# Altium schematic sheet Schematic.SchDoc(Schematic)
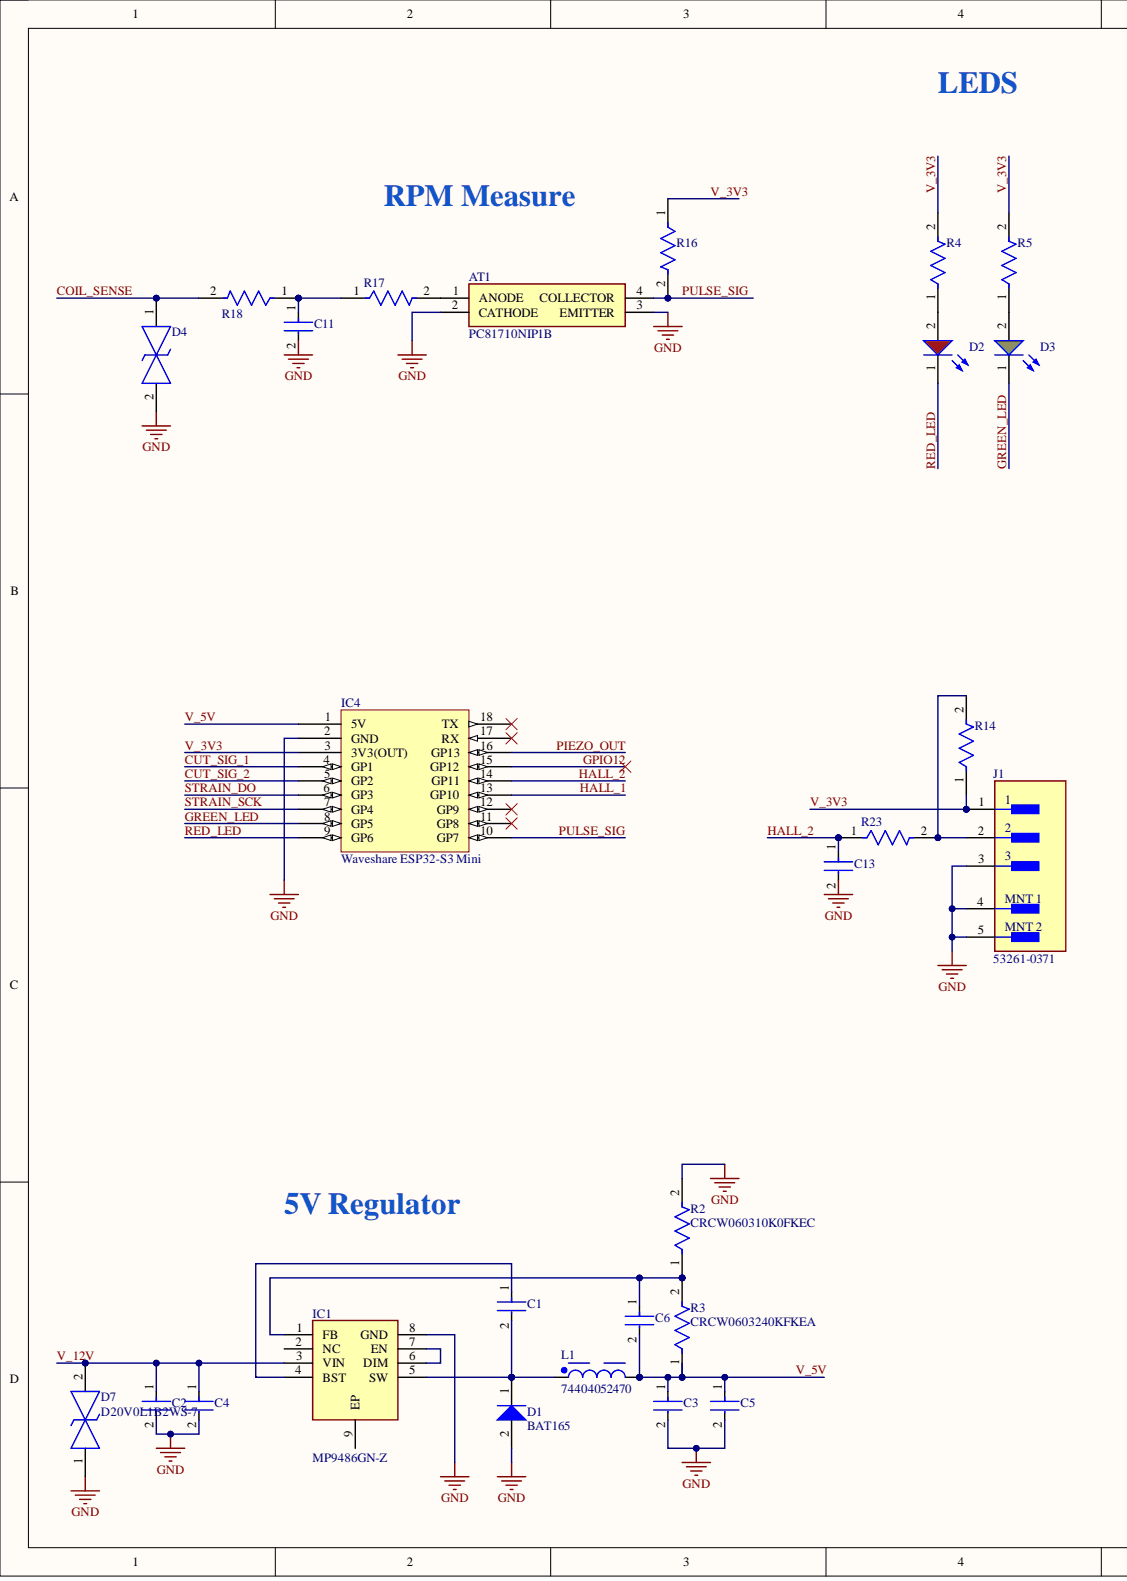
[diagram p0: Schematic.SchDoc, left]
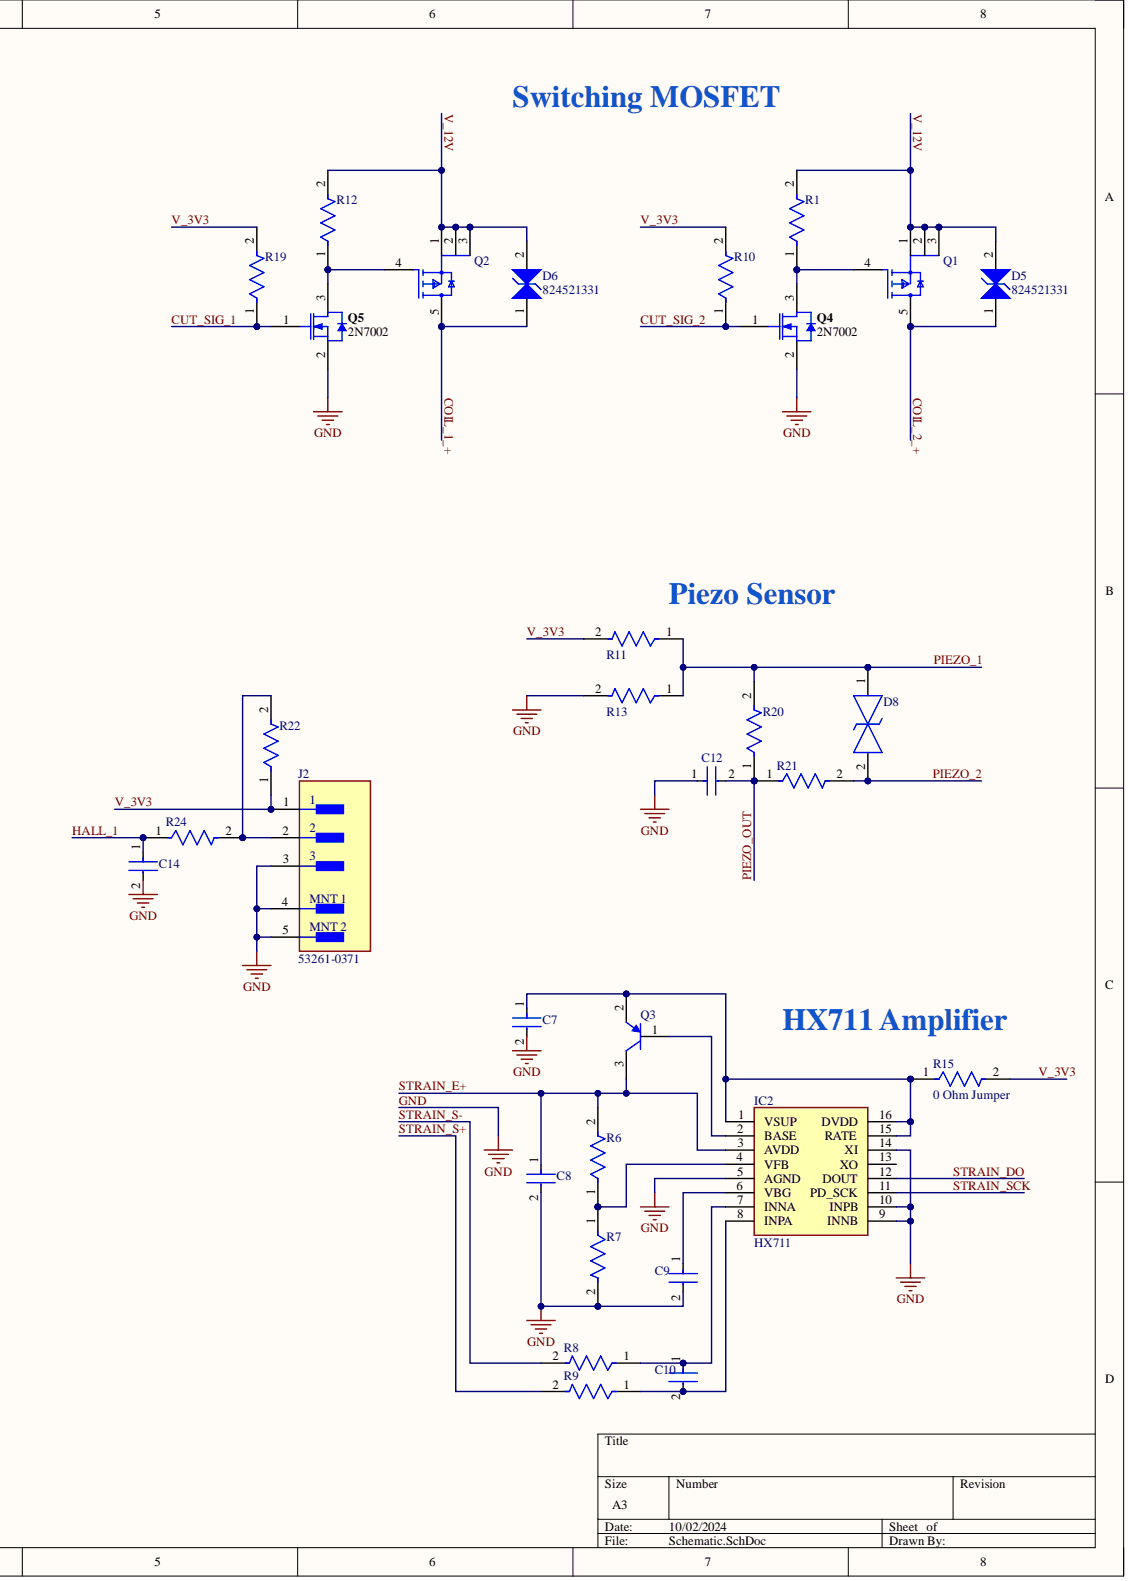
[diagram p1: Schematic.SchDoc, right]

## Parts
AT1  PC81710NIP1B
C1  C0603C104K3PACTU  (value not drawn)
C2  C3216X5R1E476M160AC  (value not drawn)
C3  C3216X5R1E476M160AC  (value not drawn)
C4  C0603C104K3PACTU  (value not drawn)
C5  C0603C225K4PACTU  (value not drawn)
C6  C0603C104K3PACTU  (value not drawn)
C7  C0603C106M8PACTU  (value not drawn)
C8  C0603C106M8PACTU  (value not drawn)
C9  C0603C104K3PACTU  (value not drawn)
C10  C0603C104K3PACTU  (value not drawn)
C11  C0603C333K1RECAUTO  (value not drawn)
C12  C0603C473K3RACTU  (value not drawn)
C13  CL10A105KA8NNNC  (value not drawn)
C14  CL10A105KA8NNNC  (value not drawn)
D1  BAT165
D2  150080RS75000  (value not drawn)
D3  150080GS75000  (value not drawn)
D4  D20V0L1B2WS-7
D5  824521331
D6  824521331
D7  D20V0L1B2WS-7
D8  D20V0L1B2WS-7
IC1  MP9486GN-Z
IC2  HX711
IC4  Waveshare ESP32-S3 Mini
J1  53261-0371
J2  53261-0371
L1  74404052470
Q1  SQJ459EP-T1_GE3  (value not drawn)
Q2  SQJ459EP-T1_GE3  (value not drawn)
Q3  MMBT4403LT1G  (value not drawn)
Q4  2N7002
Q5  2N7002
R1  CRCW060310K0FKEC
R2  CRCW060310K0FKEC
R3  CRCW0603240KFKEA
R4  CRCW0603100RFKEB  (value not drawn)
R5  CRCW0603100RFKEB  (value not drawn)
R6  CRCW060310K0FKEC
R7  CRCW06038K20FKEC  (value not drawn)
R8  CRCW0603100RFKEB  (value not drawn)
R9  CRCW0603100RFKEB  (value not drawn)
R10  CRCW060310K0FKEC
R11  CRCW060310K0FKEC
R12  CRCW060310K0FKEC
R13  CRCW060310K0FKEC
R14  CRCW06033K30FKEA  (value not drawn)
R15  0 Ohm Jumper
R16  CRCW060310K0FKEC
R17  RMCF0603FT2K20  (value not drawn)
R18  CRCW060310K0FKEC
R19  CRCW060310K0FKEC
R20  CRCW06032M00FKEA  (value not drawn)
R21  CRCW060310K0FKEC
R22  CRCW06033K30FKEA  (value not drawn)
R23  CRCW060320K0FKEA  (value not drawn)
R24  CRCW060320K0FKEA  (value not drawn)

## Nets
COIL_1_+: D6.1 Q2.5
COIL_2_+: D5.1 Q1.5
COIL_SENSE: D4.1 R18.2
CUT_SIG_1: IC4.4(GP1) Q5.1 R19.1
CUT_SIG_2: IC4.5(GP2) Q4.1 R10.1
GND: AT1.2(CATHODE) AT1.3(EMITTER) C2.2 C3.2 C4.2 C5.2 C7.2 C8.2 C9.2 C11.2 C12.1 C13.2 C14.2 D1.2 D4.2 D7.1 IC1.8(GND) IC2.5(AGND) IC2.9(INNB) IC2.10(INPB) IC2.14(XI) IC4.2(GND) J1.3 J1.4 J1.5 J2.3 J2.4 J2.5 Q4.2 Q5.2 R2.2 R7.2 R13.2
GPIO12: IC4.15(GP12)
GREEN_LED: D3.1 IC4.8(GP5)
HALL_1: C14.1 IC4.13(GP10) R24.1
HALL_2: C13.1 IC4.14(GP11) R23.1
PIEZO_1: D8.1 R11.1 R13.1 R20.2
PIEZO_2: D8.2 R21.2
PIEZO_OUT: C12.2 IC4.16(GP13) R20.1 R21.1
PULSE_SIG: AT1.4(COLLECTOR) IC4.10(GP7) R16.2
RED_LED: D2.1 IC4.9(GP6)
STRAIN_DO: IC2.12(DOUT) IC4.6(GP3)
STRAIN_E+: C8.1 IC2.3(AVDD) Q3.3 R6.2
STRAIN_S+: R9.2
STRAIN_S-: R8.2
STRAIN_SCK: IC2.11(PD_SCK) IC4.7(GP4)
V_3V3: IC4.3(3V3(OUT)) J1.1 J2.1 R4.2 R5.2 R10.2 R11.2 R14.1 R15.2 R16.1 R19.2 R22.1
V_5V: C3.1 C5.1 C6.2 IC4.1(5V) L1.2 R3.1
V_12V: C2.1 C4.1 D5.2 D6.2 D7.2 IC1.3(VIN) Q1.1 Q1.2 Q1.3 Q2.1 Q2.2 Q2.3 R1.2 R12.2
NetAT1_1: AT1.1(ANODE) R17.2
NetC1_1: C1.1 IC1.4(BST)
NetC1_2: C1.2 D1.1 IC1.5(SW) L1.1
NetC6_1: C6.1 IC1.1(FB) R2.1 R3.2
NetC7_1: C7.1 IC2.1(VSUP) IC2.15(RATE) IC2.16(DVDD) Q3.2 R15.1
NetC9_1: C9.1 IC2.6(VBG)
NetC10_1: C10.1 IC2.7(INNA) R8.1
NetC10_2: C10.2 IC2.8(INPA) R9.1
NetC11_1: C11.1 R17.1 R18.1
NetD2_2: D2.2 R4.1
NetD3_2: D3.2 R5.1
NetIC1_6: IC1.6(DIM) IC1.7(EN)
NetIC2_2: IC2.2(BASE) Q3.1
NetIC2_4: IC2.4(VFB) R6.1 R7.1
NetJ1_2: J1.2 R14.2 R23.2
NetJ2_2: J2.2 R22.2 R24.2
NetQ1_4: Q1.4 Q4.3 R1.1
NetQ2_4: Q2.4 Q5.3 R12.1

Not connected: IC1 2(NC) 9(EP); IC2 13(XO); IC4 11(GP8) 12(GP9) 17(RX) 18(TX)

## Library data of the parts
AT1 = PC81710NIP1B: Attenuator or Isolator; Manufacturer_Name: Sharp Microelectronics; Component Kind: Standard; Datasheet Link: https://global.sharp/products/device/lineup/data/pdf/datasheet/PC8171xNSZ1B_e.pdf; Height: 4.2mm; Manufacturer_Part_Number: PC81710NIP1B; Mouser Part Number: 852-PC81710NIP1B; Mouser Price/Stock: https://www.mouser.co.uk/ProductDetail/Sharp-Microelectronics/PC81710NIP1B?qs=MLItCLRbWswN8lAuXmPENg%3D%3D
C1 C4 C6 C9 C10 = CMP-03020-000352-2: C0603 0.10 μF X5R 30ppm/°C 10.00% 25 V; Manufacturer: KEMET; Automotive Grade: Grade 1; Capacitance: 0.10 μF; Material: Ceramic; Tolerance: 0.1; Value: 0.10 μF; Voltage Rating: 25 V; Automotive: Yes; Category: Cap; Component Kind: Standard; ComponentLink2Description: Datasheet; ComponentLink2URL: https://api.kemet.com/component-edge/download/datasheet/C0603C100J5GACAUTO.pdf; Device Class L1: Passive Components; Device Class L2: Capacitors; Device Class L3: Ceramic Capacitors; Height: 0.87mm; Lead Free: Yes; Library Name: Altium Content Vault; Manufacturer Part Number: C0603C104K3PACTU; Mouser Description: Multilayer Ceramic Capacitors MLCC - SMD/SMT 25volts 0.1uF 10% X5R; Octopart: https://octopart.com/c0603c104k3pactu-kemet-19022554; Package: C0603C-CF; RoHS: TRUE; Temperature: -55°C to +125°C; Temperature Characteristic: X5R; Temperature Coefficient: 30ppm/°C; Temperature Range High: +125°C; Temperature Range Low: -55°C
C2 C3 = CMP-2000-05397-3: Multilayer Ceramic Capacitors 47uF ±20% 25V X5R SMD 1206; Capacitance: 47uF; Case Code (Imperial): 1206; Case Code (Metric): 3216; Case/Package: 1206; Component Kind: Standard; Depth: 1.6mm; Dielectric: X5R; Dielectric Material: Ceramic; Height: 1.6mm; Lead Free: Lead Free; Length: 0.126inch; Library Name: Altium Content Vault; Max Operating Temperature: 85°C; Min Operating Temperature: -55°C; Mount: Surface Mount; Package Quantity: 1; Packaging: Tape and Reel; REACH SVHC: No SVHC; Resistor Type: Ceramic; RoHS Compliant: Yes; Series: C; Termination: SMD/SMT; Thickness: 0.071inch; Tolerance: 20%; Voltage: 25V; Voltage Rating: 25V; Voltage Rating (DC): 25V; Weight: 0.000571oz; Width: 0.063inch
C5 = CMP-2006-02259-2: CAP CER 2.2UF 16V X5R 0603; Dielectric Material: Ceramic; Dissipation Factor: 5%; Tolerance: 10%; Capacitance: 2.2uF; Case Code (Imperial): 0603; Case Code (Metric): 1608; Case/Package: 0603; Component Kind: Standard; Construction: Flat; Contact Plating: Tin; Depth: 0.8mm; Dielectric: X5R; Height: 0.8mm; Lead Free: Lead Free; Length: 1.6mm; Library Name: Altium Content Vault; Max Operating Temperature: 85°C; Min Operating Temperature: -55°C; Mount: Surface Mount; Number of Pins: 2; Package Quantity: 1; Packaging: Tape and Reel; Radiation Hardening: No; REACH SVHC: No SVHC; RoHS Compliant: Yes; Series: C; Termination: SMD/SMT; Thickness: 0.034inch; Voltage: 16V; Voltage Rating: 16V; Voltage Rating (DC): 16V; Width: 0.8mm
C7 C8 = CMP-03020-034654-1: CAP CER 10UF 10V X5R 0603; Component Kind: Standard; Library Name: Altium Content Vault; RoHS Compliant: Yes
C11 = CMP-00212-78735637-1: C0603C333K1RECAUTO 0603 (1608METRIC) Ceramic Capacitor Esd SMD Auto X7R 100V 0.033MUF ±10% X7R; Capacitance: 33nF; Component Kind: Standard; Dielectric: X7R; Library Name: Altium Content Vault; NexarPartId: 78735637; Packaging: Cut Tape; RoHS: Non-Compliant; Voltage Rating (DC): 100V
C12 = CMP-2006-03882-2: CAP CER 0.047UF 25V 10% X7R 0603; Manufacturer: KEMET; Automotive Grade: Grade 1; Capacitance: 47,000 pF; Material: Ceramic; Temperature Coefficient: 30ppm/°C; Tolerance: 0.1; Value: 47,000 pF; Automotive: Yes; Category: Cap; Component Kind: Standard; ComponentLink2Description: Datasheet; ComponentLink2URL: https://api.kemet.com/component-edge/download/datasheet/C0603C100J5GACAUTO.pdf; Device Class L1: Passive Components; Device Class L2: Capacitors; Device Class L3: Ceramic Capacitors; Digikey Description: CAP CER 0.047UF 25V 10% X7R 0603; Height: 0.87mm; Lead Free: Yes; Library Name: Altium Content Vault; Manufacturer Part Number: C0603C473K3RACTU; Mouser Description: Multilayer Ceramic Capacitor, C Series, 0.047 - F, - 10%, X7R, 25 V, 0603 [1608 Metric] Rohs Compliant: Yes; Octopart: https://octopart.com/c0603c473k3ractu-kemet-3834514; Package: C0603C-CF; RoHS: TRUE; Temperature: -55°C to +125°C; Temperature Characteristic: X7R; Temperature Range High: +125°C; Temperature Range Low: -55°C; Voltage Rating: 25 V
C13 C14 = CMP-13271-004127-1: Cap Ceramic 1uF 25V X5R ±10% Pad SMD 0603 +85°C T/R; Capacitance: 1uF; Case Code (Imperial): 0603; Case Code (Metric): 1608; Case/Package: 0603; Component Kind: Standard; Contact Plating: Tin; Depth: 0.8mm; Dielectric: X5R; Height: 0.8mm; Lead Free: Lead Free; Length: 1.6mm; Library Name: Altium Content Vault; Material: Ceramic; Max Operating Temperature: 85°C; Min Operating Temperature: -55°C; Mount: Surface Mount; Package Quantity: 1; Packaging: Tape and Reel; Radiation Hardening: No; Thickness: 0.035inch; Tolerance: 10%; Voltage: 25V; Voltage Rating: 25V; Voltage Rating (DC): 25V; Width: 0.031inch
D1 = CMP-1183-00004-1: Medium Power AF Schottky Diode, SOD323, Reel, Green; Manufacturer: Infineon; Configuration: Single; CT (max): 12Â pF; Green: TRUE; IF (max): 750Â mA; VF (max): 700Â mV; VR (max): 40Â V; Component Kind: Standard; ComponentLink1Description: Manufacturer URL; ComponentLink1URL: http://www.infineon.com/; ComponentLink2Description: Datasheet; ComponentLink2URL: http://www.infineon.com/dgdl/bat165series.pdf?folderId=db3a304313d846880113def5812204a1&fileId=db3a304313d846880113df01f69804d6; ComponentLink3Description: Package Specification; ComponentLink3URL: http://www.infineon.com/dgdl/SOD323-Package_Overview.pdf?folderId=db3a30431936bc4b011952aab84f3bbe&fileId=db3a30431936bc4b011952beed653be3; Library Name: Altium Content Vault; Mounting Technology: Surface Mount; PackageDescription: 2-Pin Small Outline Diode Package, 1.25 x 1.7 mm Body; PackageReference: SOD323; Packing: Reel; PartNumber: BAT165
D2 = CMP-1426-00011-2: NexarPartId: 29717781; Color: Red; Dominant Wavelength: 625nm; Forward Current: 100mA; Forward Voltage: 2V; Lens Style: Clear, Rectangular; Luminous Intensity: 150mcd; Max Reverse Voltage (DC): 5V; Peak Wavelength: 630nm; Test Current: 20mA; Viewing Angle: 140°; Case Code (Imperial): 0805; Case Code (Metric): 2012; Case/Package: 0805; Component Kind: Standard; Height: 700µm; Length: 2mm; Library Name: Altium Content Vault; Max Operating Temperature: 85°C; Min Operating Temperature: -40°C; Mount: Surface Mount; Packaging: Tape and Reel; REACH SVHC: Unknown; RoHS: Compliant; Wavelength: 625nm; Width: 1.25mm
D3 = CMP-1426-00007-2: NexarPartId: 29717780; Color: Green; Dominant Wavelength: 525nm; Forward Current: 100mA; Forward Voltage: 3.2V; Lens Style: Clear, Rectangular; Luminous Intensity: 450mcd; Max Junction Temperature (Tj): 95°C; Max Reverse Voltage (DC): 5V; Peak Wavelength: 515nm; Power Dissipation: 105mW; Test Current: 20mA; Viewing Angle: 140°; Wavelength: 520nm; Case Code (Imperial): 0805; Case Code (Metric): 2012; Case/Package: 0805; Component Kind: Standard; Height: 700µm; Length: 2mm; Library Name: Altium Content Vault; Max Operating Temperature: 85°C; Min Operating Temperature: -40°C; Mount: Surface Mount; Packaging: Tape and Reel; REACH SVHC: Unknown; Reverse Voltage: 5V; RoHS: Compliant; Width: 1.25mm
D4 D7 D8 = D20V0L1B2WS-7: TVS Diode (Bi-directional); Manufacturer_Name: Diodes Incorporated; Component Kind: Standard; Datasheet Link: https://www.diodes.com/assets/Datasheets/D20V0L1B2WSQ.pdf; Height: 1.2mm; Manufacturer_Part_Number: D20V0L1B2WS-7
D5 D6 = CMP-03913-71101627-1: 824521331 TVS diode [Würth Elektronik] 824521331 TVS diode; Breakdown Voltage: 38.65V; Clamping Voltage: 53.3V; Leakage Current: 1µA; Number of Bidirectional Channels: 1; Peak Pulse Current: 11.3A; Peak Pulse Power: 600W; Polarity: Bidirectional; Power Line Protection: No; Reverse Standoff Voltage: 33V; Component Kind: Standard; Composition: Zener; Height: 2.4mm; Length: 4.32mm; Library Name: Altium Content Vault; Max Breakdown Voltage: 38.65V; Max Operating Temperature: 150°C; Min Breakdown Voltage: 38.65V; Min Operating Temperature: -65°C; Mount: Surface Mount; NexarPartId: 71101627; Number of Pins: 2; Packaging: Cut Tape; REACH SVHC: Unknown; RoHS: Compliant; Width: 3.62mm
IC1 = MP9486GN-Z: Integrated Circuit; Manufacturer_Name: Monolithic Power Systems (MPS); Component Kind: Standard; Datasheet Link: https://www.monolithicpower.com/en/documentview/productdocument/index/version/2/document_type/Datasheet/lang/en/sku/MP9486/; Height: 1.7mm; Manufacturer_Part_Number: MP9486GN-Z; Mouser Part Number: 946-MP9486GN-Z; Mouser Price/Stock: https://www.mouser.co.uk/ProductDetail/Monolithic-Power-Systems-MPS/MP9486GN-Z?qs=YCa%2FAAYMW039h5ckyQnXtA%3D%3D
IC2 = HX711: Integrated Circuit; Manufacturer_Name: AVIA; Component Kind: Standard; Datasheet Link: https://cdn.sparkfun.com/datasheets/Sensors/ForceFlex/hx711_english.pdf; Height: 1.6mm; Manufacturer_Part_Number: HX711
IC4 = CMP-00000-1: WaveShare ESP32-S3 Mini Development Board ESP32-S3FH4R2 240MHz 2.4GHz Wi-Fi Bluetooth 5; Component Kind: Standard; Datasheet: https://www.waveshare.com/wiki/ESP32-S3-Zero; Library Name: Sandros Projects
J1 J2 = CMP-04776-000071-1: CONN HEADER SMD R/A 3POS 1.25MM; Manufacturer: Molex; Conn Gender: Male; Conn Orientation: Right Angle; Current Rating: 1A; Number Of Contacts: 3; Voltage Rating: 125V; Ambient Temperature Range High: +105°C; Ambient Temperature Range Low: -40°C; Automotive: No; Category: Conn; Component Kind: Standard; ComponentLink2Description: Datasheet; ComponentLink2URL: https://www.molex.com/webdocs/datasheets/pdf/en-us/0532610371_PCB_HEADERS.pdf; Contact Material: Tin,Nickel; Device Class L1: Connectors; Device Class L2: Headers and Wire Housings; Device Class L3: unset; Digikey Description: CONN HEADER SMD R/A 3POS 1.25MM; Footprint Url: https://www.molex.com/pdm_docs/sd/532610371_sd.pdf; Height: 3.6mm; Lead Free: Yes; Library Name: Altium Content Vault; Manufacturer Part Number: 53261-0371; Mouser Description: 1.25mm (.049") Pitch PicoBlade Header, Surface Mount, Right Angle, Lead-free, 3 Circuits; Number Of Rows: 1; Octopart: https://octopart.com/53261-0371-molex-655314; Package: 0; Pitch: 1.25mm; RoHS: TRUE; Temperature: -40°C to +105°C; Temperature Range High: +105°C; Temperature Range Low: -40°C
L1 = CMP-03913-61645225-1: 74404052470 Inductors, Fixed, WE-LQS SMD 5020 47uH 0.8A 521mOhms; Current Rating: 800mA; DC Resistance (DCR): 521mΩ; Inductance: 47µH; Inductance Tolerance: 20%; Saturation Current: 950mA; Self Resonant Frequency: 11MHz; Shielding: Shielded; Test Frequency: 100kHz; Ambient Temperature Range High: 85°C; Ambient Temperature Range Low: -40°C; Case/Package: Nonstandard; Component Kind: Standard; Composition: Wirewound; Height: 2mm; Height - Seated (Max): 2.0066mm; Length: 5mm; Library Name: Altium Content Vault; Max Operating Temperature: 125°C; Min Operating Temperature: -40°C; Mount: Surface Mount; NexarPartId: 61645225; Packaging: Tape & Reel (TR); RoHS: Compliant; Tolerance: 20%; Width: 5mm
Q1 Q2 = CMP-02550-000107-1: MOSFET P-CH 60V 52A POWERPAKSO-8; Manufacturer: Vishay; Automotive Grade: Grade 0; Continuous Drain Current: -52A; Depletion Mode: False; Drain To Source Breakdown Voltage: -60V; Drain To Source Resistance: 0.0155Ω; Gate Charge At Vgs: 73nC @ -10V; Gate To Source Voltage: 20V; Input Capacitace At Vds: 3448pF @ -30V; Max Forward Diode Voltage: -1.2V; Max Junction Temp: +175°C; Number Of Channels: 1; Power Dissipation: 83W; Pulse Drain Current: -200A; Rthja Max: 65°C/W; Standoff Height: 0mm; Threshold Vgs Max: -2.5V; Threshold Vgs Min: -1.5V; Transconductance: 40S; Turn Off Delay Time: 88ns; Turn On Delay Time: 12ns; Typ Forward Diode Voltage: -0.8V; Automotive: Yes; Category: Trans; Component Kind: Standard; ComponentLink2Description: Datasheet; ComponentLink2URL: http://www.vishay.com/docs/66963/sqj459ep.pdf; Device Class L1: Discrete Semiconductors; Device Class L2: Transistors; Device Class L3: MOSFETs; Digikey Description: MOSFET P-CH 60V 52A POWERPAKSO-8; Drain To Source Voltage: -60V; Height: 1.267mm; Lead Free: Yes; Library Name: Altium Content Vault; Manufacturer Part Number: SQJ459EP-T1_GE3; Number Of P Channels: 1; Octopart: https://octopart.com/sqj459ep-t1_ge3-vishay-73080141; Package: C15-1203-SINGLE; RoHS: TRUE; Temperature: -55°C to +175°C; Temperature Range High: +175°C; Temperature Range Low: -55°C
Q3 = CMP-1048-00539-1: Switching Transistor, PNP Silicon, 3-Pin SOT-23, Pb-Free, Tape and Reel; Manufacturer: On Semiconductor; fT Min (MHz): 200; IC Continuous (A): -0.6; Component Kind: Standard; ComponentLink1Description: Manufacturer URL; ComponentLink1URL: http://www.onsemi.com/; ComponentLink2Description: Datasheet; ComponentLink2URL: http://www.onsemi.cn/pub_link/Collateral/MMBT4403LT1-D.PDF; ComponentLink3Description: Package Specification; ComponentLink3URL: http://www.onsemi.cn/pub_link/Collateral/318-08.PDF; DatasheetVersion: Rev. 12; Library Name: Altium Content Vault; Mounting Technology: Surface Mount; PackageDescription: 3-Pin Small Outline Transistor, Body 2.92 x 1.3 mm, Pitch 0.95 mm; PackageReference: SOT-23-3-318-08; PackageVersion: Rev. AP, 11/2009; Packing: Tape and Reel; PartNumber: MMBT4403LT1G; Polarity: PNP; RoHS: TRUE
Q4 Q5 = CMP-1149-00026-1: N-Channel OptiMOS Small-Signal-Transistor, 60 V VDS, 0.3 A ID, -55 to 150 degC, PG-SOT23, Reel, Green; Manufacturer: Infineon; Green: TRUE; ID (max): 0.3Â A; IDpuls (max): 1.2Â A; Ptot (max): 0.5Â W; QG: 0.4Â nC; RDS (on) (max): 3,000 mOhm; RDS (on) (max) (@4.5V): 4,000 mOhm; RthJA (max): 250 K/W; VDS (max): 60 V; VGS(th) (max): 2.5Â V; VGS(th) (min): 1.5Â V; Component Kind: Standard; ComponentLink1Description: Manufacturer URL; ComponentLink1URL: http://www.infineon.com/; ComponentLink2Description: Datasheet; ComponentLink2URL: http://www.infineon.com/dgdl/2N7002_Rev2.4.pdf?folderId=db3a3043156fd573011622e10b5c1f67&fileId=db3a304319bc939a0119c2a9461e79e6; ComponentLink3Description: Package Specification; ComponentLink3URL: http://www.infineon.com/dgdl/SOT23-Package_Overview.pdf?folderId=db3a30431936bc4b0119532290053c66&fileId=db3a30431936bc4b0119533468123c85; DatasheetVersion: Rev. 2.4; Halogen-free: TRUE; Library Name: Altium Content Vault; Mounting Technology: Surface Mount; PackageDescription: 3-Pin Small Outline Transistor Package, 2.9 x 1.3 mm Body, 0.95 mm Pitch; PackageReference: PG-SOT23; PackageVersion: Apr-08; Packing: Reel; PartNumber: 2N7002
R1 R2 R6 R10-R13 R16 R18 R19 R21 = CMP-02407-006151-1: RES Thick Film, 10kΩ, 1%, 0.1W, 100ppm/°C, 0603; Max Power Dissipation: 100mW; Resistance: 10kR; Case Code (Imperial): 0603; Case Code (Metric): 1608; Case/Package: 0603; Component Kind: Standard; Composition: Thick Film; Contact Plating: Tin; Depth: 0.85mm; Features: Automotive AEC-Q200; Height: 0.02inch; Lead Free: Lead Free; Length: 0.061inch; Library Name: Altium Content Vault; Max Operating Temperature: 155°C; Military Standard: Not; Min Operating Temperature: -55°C; Number of Terminations: 2; Packaging: Tape and Reel; Power Rating: 100mW; Radiation Hardening: No; Temperature Coefficient: 100ppm/°C; Tolerance: 1%; Width: 0.033inch
R3 = CMP-02407-008686-1: RES Thick Film, 240kΩ, 1%, 0.1W, 100ppm/°C, 0603; Max Power Dissipation: 100mW; Resistance: 240kR; Voltage Rating: 75V; Case Code (Imperial): 0603; Case Code (Metric): 1608; Case/Package: 0603; Component Kind: Standard; Composition: Thick Film; Contact Plating: Tin; Depth: 0.85mm; Features: Automotive AEC-Q200; Height: 0.45mm; Lead Free: Lead Free; Length: 1.55mm; Library Name: Altium Content Vault; Max Operating Temperature: 155°C; Military Standard: Not; Min Operating Temperature: -55°C; Number of Terminations: 2; Package Quantity: 10; Packaging: Tape and Reel; Power Rating: 100mW; Radiation Hardening: No; Temperature Coefficient: 100ppm/°C; Termination: SMD/SMT; Tolerance: 1%; Voltage Rating (DC): 75V; Weight: 7.1E-05oz; Width: 0.033inch
R4 R5 R8 R9 = CMP-2000-03825-2: RES Thick Film, 100Ω, 1%, 0.1W, 100ppm/°C, 0603; Max Power Dissipation: 100mW; Resistance: 100R; Voltage Rating: 75V; Case Code (Imperial): 0603; Case Code (Metric): 1608; Case/Package: 0603; Component Kind: Standard; Composition: Thick Film; Contact Plating: Tin; Depth: 0.85mm; Features: Automotive AEC-Q200; Height: 0.02inch; Lead Free: Lead Free; Length: 1.55mm; Library Name: Altium Content Vault; Max Operating Temperature: 155°C; Military Standard: Not; Min Operating Temperature: -55°C; Number of Terminations: 2; Package Quantity: 10000; Packaging: Tape and Reel; Power Rating: 100mW; Radiation Hardening: No; Temperature Coefficient: 100ppm/°C; Termination: SMD/SMT; Tolerance: 1%; Voltage Rating (DC): 75V; Weight: 7.1E-05oz; Width: 0.033inch
R7 = CMP-02407-002238-1: RES Thick Film, 8.2kΩ, 1%, 0.1W, 100ppm/°C, 0603; Max Power Dissipation: 100mW; Resistance: 8.2kR; Case/Package: 0603; Component Kind: Standard; Composition: Thick Film; Contact Plating: Tin; Depth: 0.85mm; Features: Automotive AEC-Q200; Height: 0.02inch; Lead Free: Lead Free; Length: 0.061inch; Library Name: Altium Content Vault; Max Operating Temperature: 155°C; Military Standard: Not; Min Operating Temperature: -55°C; Number of Terminations: 2; Package Quantity: 20000; Packaging: Tape and Reel; Radiation Hardening: No; Temperature Coefficient: 100ppm/°C; Tolerance: 1%; Width: 0.033inch
R14 R22 = CMP-2100-03614-2: RES Thick Film, 3.3kΩ, 1%, 0.1W, 100ppm/°C, 0603; Max Power Dissipation: 100mW; Resistance: 3.3kR; Voltage Rating: 75V; Case Code (Imperial): 0603; Case Code (Metric): 1608; Case/Package: 0603; Component Kind: Standard; Composition: Thick Film; Contact Plating: Tin; Depth: 0.85mm; Features: Automotive AEC-Q200; Height: 0.45mm; Lead Free: Lead Free; Length: 1.55mm; Library Name: Altium Content Vault; Max Operating Temperature: 155°C; Military Standard: Not; Min Operating Temperature: -55°C; Mount: Surface Mount; Number of Terminations: 2; Package Quantity: 10; Packaging: Tape and Reel; Power Rating: 100mW; Radiation Hardening: No; Temperature Coefficient: 100ppm/°C; Termination: SMD/SMT; Tolerance: 1%; Voltage Rating (DC): 75V; Weight: 7.1E-05oz; Width: 0.85mm; Working Voltage: 75V
R15 = CMP-02407-004162-1: RES Thick Film, 0Ω, 5%, 0.33W, 200ppm/°C, 0603; Component Kind: Standard; Library Name: Altium Content Vault
R17 = CMP-26527-000159-1: 2.2kΩ ±1% 0.1W 0603 Thick Film Chip Resistor AEC-Q200 compliant; Max Power Dissipation: 100mW; Resistance: 2.2kR; Temperature Coefficient: 100ppm/°C; Case Code (Imperial): 0603; Case Code (Metric): 1608; Case/Package: 0603; Component Kind: Standard; Contact Plating: Tin; Features: Automotive AEC-Q200; Height: 0.022inch; Lead Free: Lead Free; Length: 0.061inch; Library Name: Altium Content Vault; Max Operating Temperature: 155°C; Min Operating Temperature: -55°C; Mount: Surface Mount; Number of Terminations: 2; Packaging: Tape and Reel; Power Rating: 100mW; Radiation Hardening: No; Resistor Type: Thick Film; RoHS Compliant: Yes; Series: RMCF; Tolerance: 1%; Width: 0.031inch
R20 = CMP-2000-03189-2: RES Thick Film, 2MΩ, 1%, 0.1W, 100ppm/°C, 0603; Max Power Dissipation: 100mW; Resistance: 2MR; Voltage Rating: 75V; Case Code (Imperial): 0603; Case Code (Metric): 1608; Case/Package: 0603; Component Kind: Standard; Composition: Thick Film; Contact Plating: Tin; Depth: 0.85mm; Features: Automotive AEC-Q200; Height: 0.45mm; Lead Free: Lead Free; Length: 1.55mm; Library Name: Altium Content Vault; Max Operating Temperature: 155°C; Military Standard: Not; Min Operating Temperature: -55°C; Number of Pins: 2; Number of Terminations: 2; Package Quantity: 10; Packaging: Tape and Reel; Power Rating: 100mW; Radiation Hardening: No; Temperature Coefficient: 100ppm/°C; Termination: SMD/SMT; Tolerance: 1%; Voltage Rating (DC): 75V; Weight: 7.1E-05oz; Width: 0.033inch; Working Voltage: 75V
R23 R24 = CMP-2000-03068-2: RES Thick Film, 20kΩ, 1%, 0.1W, 100ppm/°C, 0603; Power Rating: 100mW; Resistance: 20kR; Voltage Rating: 75V; Case Code (Imperial): 0603; Case Code (Metric): 1608; Case/Package: 0603; Component Kind: Standard; Composition: Thick Film; Contact Plating: Tin; Depth: 0.85mm; Height: 0.45mm; Lead Free: Lead Free; Length: 1.55mm; Library Name: Altium Content Vault; Max Operating Temperature: 155°C; Military Standard: Not; Min Operating Temperature: -55°C; Mount: Surface Mount; Number of Pins: 2; Package Quantity: 5000; Packaging: Tape and Reel; Radiation Hardening: No; Temperature Coefficient: 100ppm/°C; Termination: SMD/SMT; Tolerance: 1%; Voltage Rating (DC): 75V; Weight: 7.1E-05oz; Width: 0.85mm
